# Altium schematic sheet Trottinette_Telemetrie_Connectors.SchDoc(Trottinette_Telemetrie_Connectors)
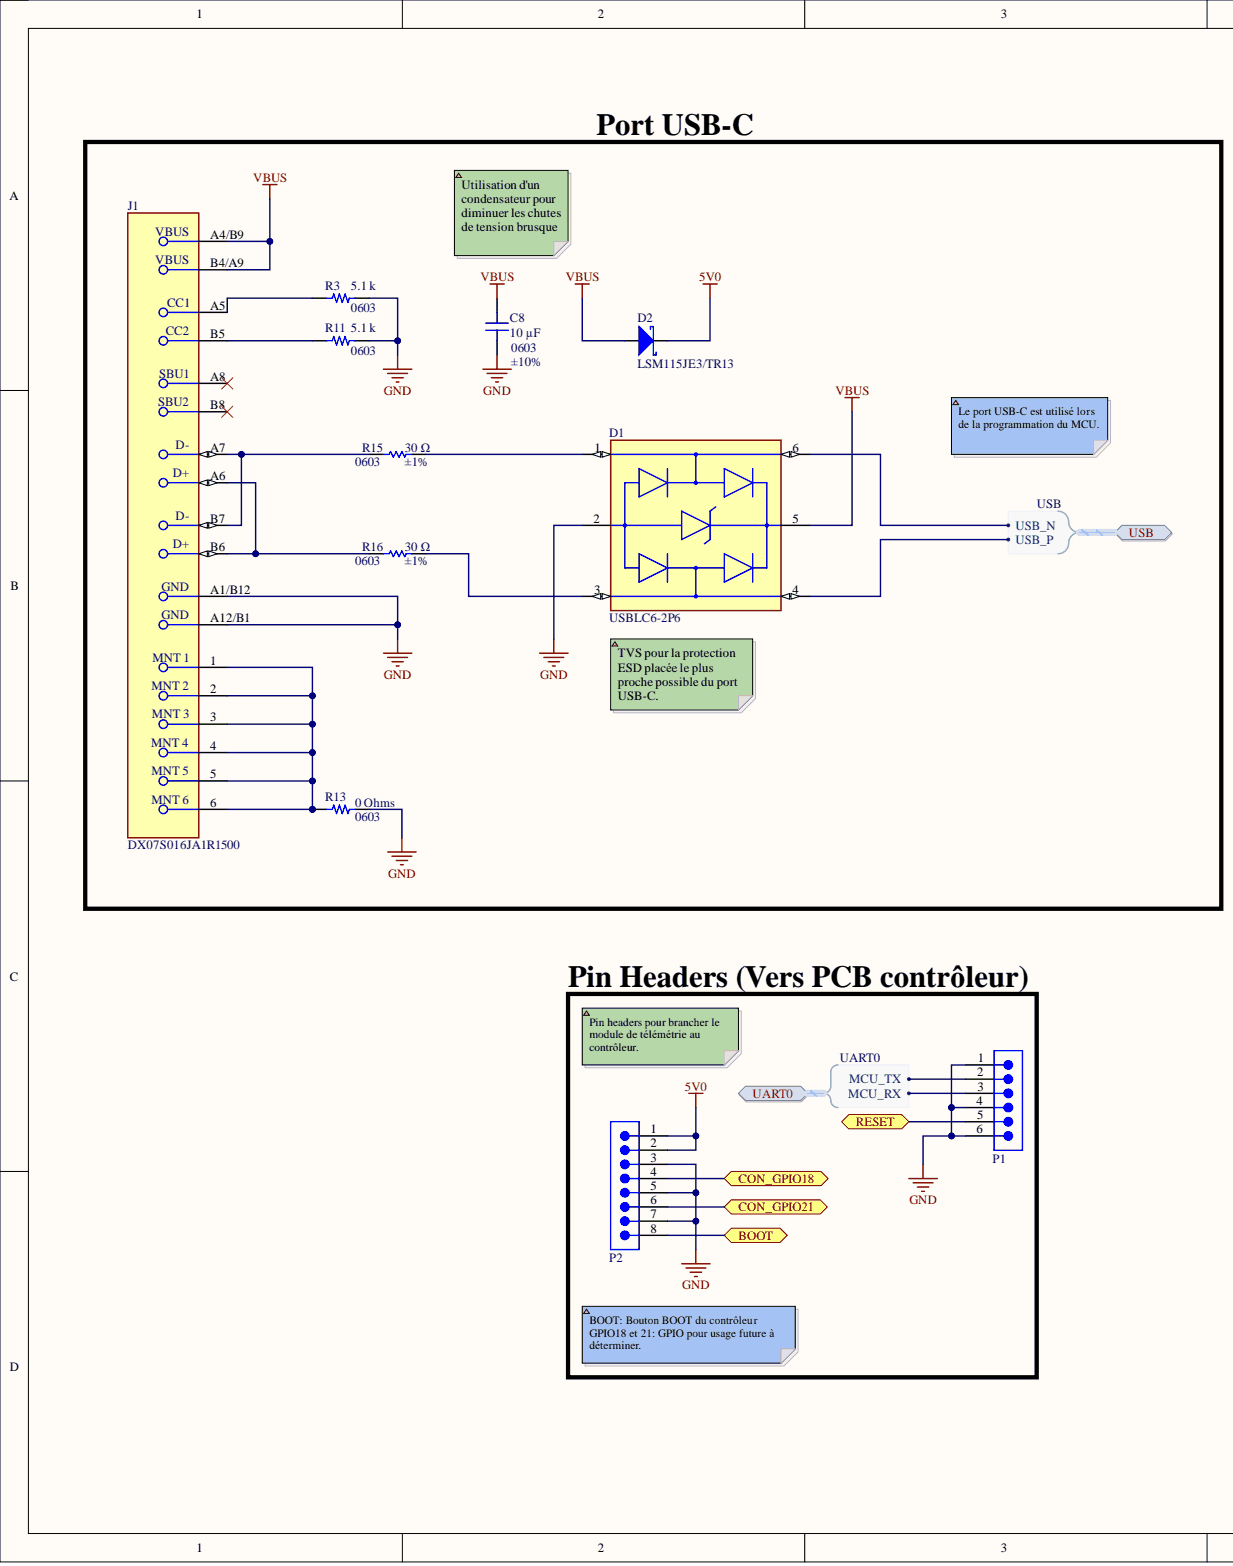
[diagram p0: Trottinette_Telemetrie_Connectors.SchDoc, left]
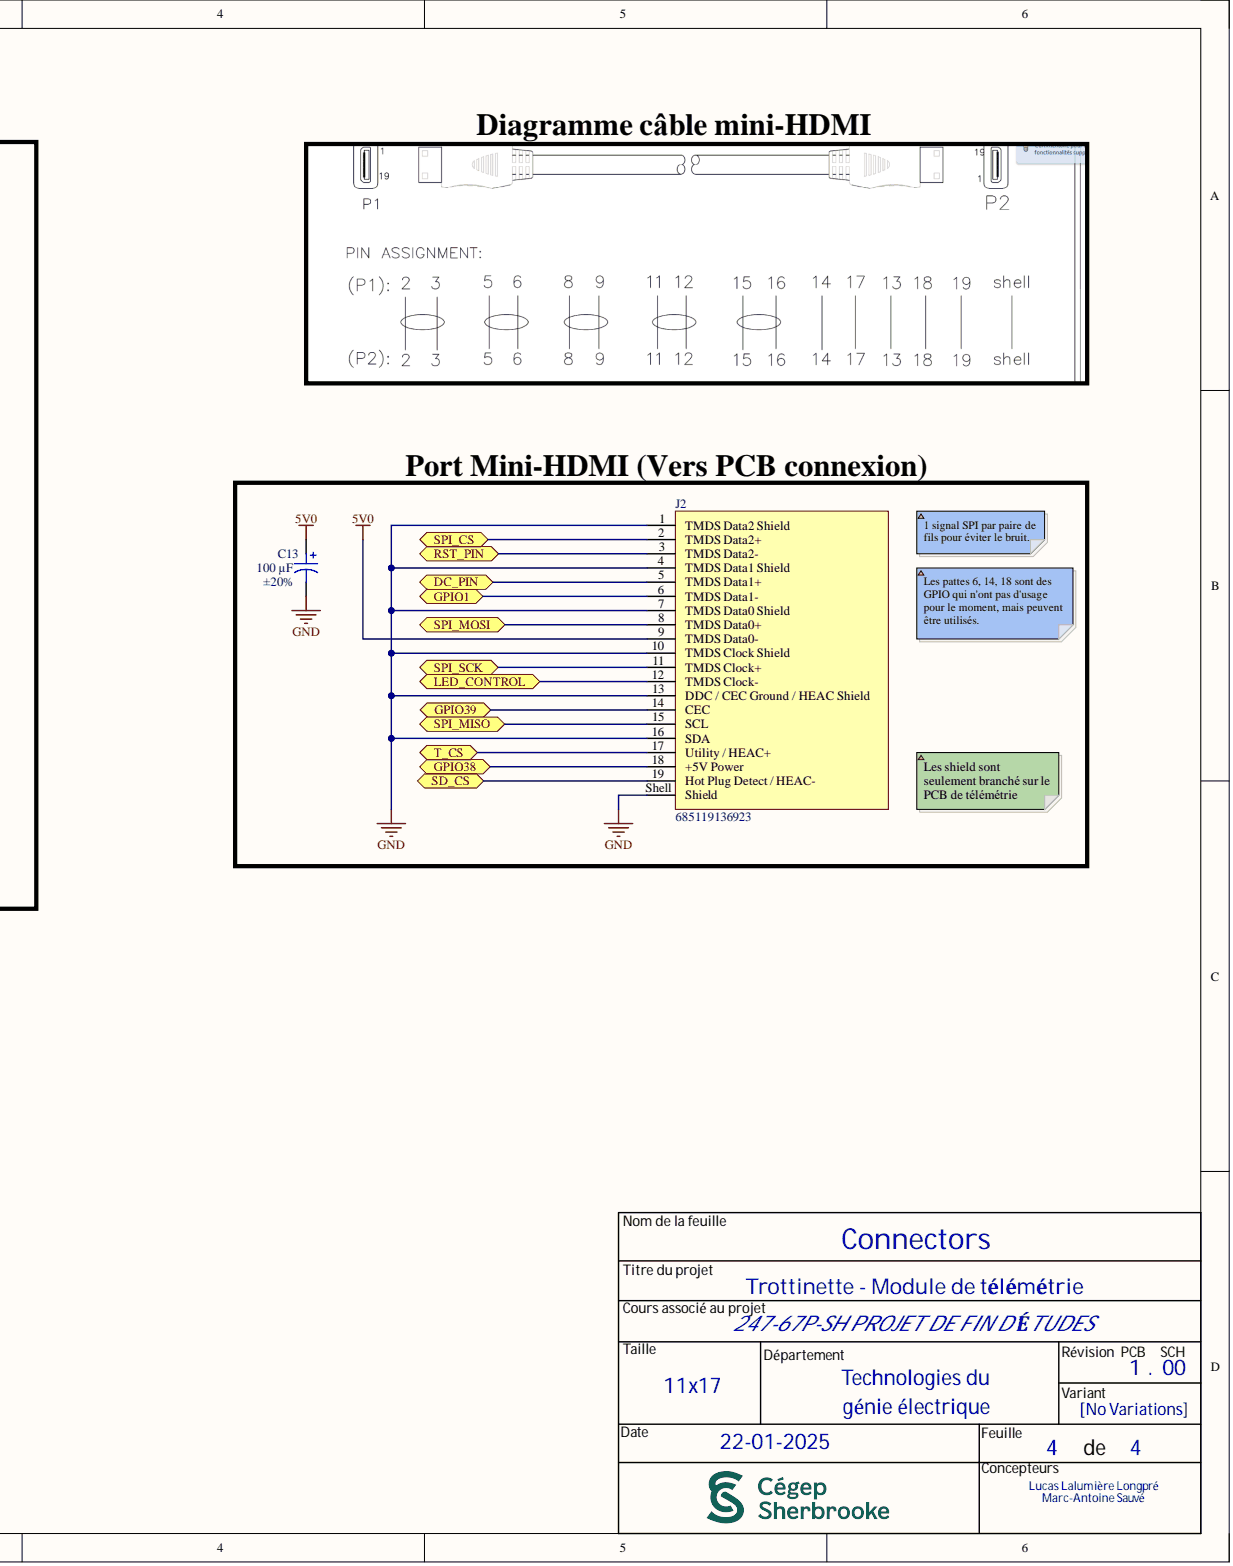
[diagram p1: Trottinette_Telemetrie_Connectors.SchDoc, right]

## Parts
C8  10 µF  Device Package: 0603  Tolerance: ±10%  [CAP 0603_1608]
C13  100 µF  Tolerance: ±20%  [CAP ALSMD 6.6MM H6.1MM]
D1  USBLC6-2P6  [FP-SOT-666-MFG]
D2  LSM115JE3/TR13  [DIOM4336X33N]
J1  DX07S016JA1R1500  [FP-DX07S016JA1R1500-MFG]
J2  685119136923  [685119136923]
P1  61300611121  [61300611121]  (value not drawn)
P2  61300811121  [61300811121]  (value not drawn)
R3  5.1 k  Package / Case: 0603  [RES 0603_1608]
R11  5.1 k  Package / Case: 0603  [RES 0603_1608]
R13  0 Ohms  Supplier Device Package: 0603  [RES 0603_1608]
R15  ERJ3EKF30R0V  [RESC1608X55X30LL15T15]  (value not drawn)
R16  ERJ3EKF30R0V  [RESC1608X55X30LL15T15]  (value not drawn)

## Ports
BOOT, CON_GPIO18, CON_GPIO21, DC_PIN, GPIO1, GPIO38, GPIO39, LED_CONTROL, RESET, RST_PIN, SD_CS, SPI_CS, SPI_MISO, SPI_MOSI, SPI_SCK, T_CS, UART0, UART0.MCU_RX, UART0.MCU_TX, USB, USB.USB_N, USB.USB_P

## Nets
5V0: C13.1 D2.1 J2.9(TMDS_Data0-) P2.1 P2.2
GND: C8.1 C13.2 D1.2 J1.A1/B12 J1.A12/B1 J2.1(TMDS_Data2_Shield) J2.4(TMDS_Data1_Shield) J2.7(TMDS_Data0_Shield) J2.10(TMDS_Clock_Shield) J2.13(DDC_/_CEC_Ground_/_HEAC_Shield) J2.16(SDA) J2.Shell(Shield) P1.1 P1.4 P1.6 P2.3 P2.5 P2.7 R3.1 R11.1 R13.2
VBUS: C8.2 D1.5 D2.2 J1.A4/B9 J1.B4/A9
BOOT: P2.8 ; port BOOT
CON_GPIO18: P2.4 ; port CON_GPIO18
CON_GPIO21: P2.6 ; port CON_GPIO21
DC_PIN: J2.5(TMDS_Data1+) ; port DC_PIN
GPIO1: J2.6(TMDS_Data1-) ; port GPIO1
GPIO38: J2.18(+5V_Power) ; port GPIO38
GPIO39: J2.14(CEC) ; port GPIO39
LED_CONTROL: J2.12(TMDS_Clock-) ; port LED_CONTROL
RESET: P1.5 ; port RESET
RST_PIN: J2.3(TMDS_Data2-) ; port RST_PIN
SD_CS: J2.19(Hot_Plug_Detect_/_HEAC-) ; port SD_CS
SPI_CS: J2.2(TMDS_Data2+) ; port SPI_CS
SPI_MISO: J2.15(SCL) ; port SPI_MISO
SPI_MOSI: J2.8(TMDS_Data0+) ; port SPI_MOSI
SPI_SCK: J2.11(TMDS_Clock+) ; port SPI_SCK
T_CS: J2.17(Utility_/_HEAC+) ; port T_CS
UART0.MCU_RX: P1.3 ; port UART0
UART0.MCU_TX: P1.2 ; port UART0
USB.USB_N: D1.6 ; port USB
USB.USB_P: D1.4 ; port USB
NetD1_1: D1.1 R15.2
NetD1_3: D1.3 R16.2
NetJ1_1: J1.1 J1.2 J1.3 J1.4 J1.5 J1.6 R13.1
NetJ1_A5: J1.A5 R3.2
NetJ1_A6: J1.A6 J1.B6 R16.1
NetJ1_A7: J1.A7 J1.B7 R15.1
NetJ1_B5: J1.B5 R11.2

Not connected: J1 A8 B8

## Library data of the parts
C8 = GRT188R61A106KE13D: CAP CER 10UF 10V X5R 0603; manufacturer: Murata Electronics; Database Table Name: Capacitors - Ceramic - 0603; Applications: Automotive; Capacitance: 10 µF; Component Kind: Standard; componentlink1description: Datasheet; componentlink1url: https://search.murata.co.jp/Ceramy/image/img/A01X/G101/ENG/GRT188R61A106KE13-01.pdf; componentlink2description: DigiKey Link; componentlink2url: https://www.digikey.com/en/products/detail/murata-electronics/GRT188R61A106KE13D/5416733; Features: Derating Recommended; lastupdated: 2/9/2024 8:10:30 PM; Library Name: Celestial Altium Library - altium_library.DbLib; Lifecycle Status: Active; Minimum Order: 1; Mounting Type: Surface Mount, MLCC; Operating Temperature: -55°C ~ 85°C; Package / Case: 0603 (1608 Metric); packaging: TapeAndReel; Part Number: GRT188R61A106KE13D; Part Status: Active; partid: 2522; price: 0.06; Ratings: AEC-Q200; series: GRT; Size / Dimension: 0.063" L x 0.031" W (1.60mm x 0.80mm); Supplier 1: DigiKey; Supplier Part Number 1: 490-12311-1-ND; Temperature Coefficient: X5R; Thickness (Max): 0.037" (0.95mm); Value: 10 µF; Voltage - Rated: 10V
C13 = 10SGV100M6.3X6.1: CAP ALUM 100UF 20% 10V SMD; manufacturer: Rubycon; Database Table Name: Capacitors - Aluminum - Electrolytic SMT; Lifetime @ Temp.: 2000 Hrs @ 105°C; Polarization: Polar; Ripple Current @ High Frequency: 85.2 mA @ 10 kHz; Ripple Current @ Low Frequency: 71 mA @ 120 Hz; Surface Mount Land Size: 0.260" L x 0.260" W (6.60mm x 6.60mm); Applications: Automotive; Capacitance: 100 µF; Component Kind: Standard; componentlink1description: Datasheet; componentlink1url: http://www.rubycon.co.jp/en/catalog/e_pdfs/aluminum/e_sgv.pdf; componentlink2description: DigiKey Link; componentlink2url: https://www.digikey.com/en/products/detail/rubycon/10SGV100M6-3X6-1/3568604; Device Package: Radial, Can - SMD; Height - Seated (Max): 0.240" (6.10mm); lastupdated: 6/3/2024 8:16:10 PM; Library Name: Celestial Altium Library - altium_library.DbLib; Lifecycle Status: Active; Minimum Order: 1; Mounting Type: Surface Mount; Operating Temperature: -55°C ~ 105°C; Package / Case: Radial, Can - SMD; packaging: TapeAndReel; Part Number: 10SGV100M6.3X6.1; Part Status: Active; partid: 8082; price: 0.08; Ratings: AEC-Q200; series: SGV; Size / Dimension: 0.248" Dia (6.30mm); Supplier 1: DigiKey; Supplier Part Number 1: 1189-2392-1-ND; value: 100 µF; Voltage - Rated: 10 V
D1 = CMP-12225-000058-1: TVS DIODE 5.25V 17V SOT666; Breakdown Voltage: 6V; Capacitance: 3.5pF; Direction: Unidirectional; Element Configuration: Dual; ESD Protection: Yes; Leakage Current: 150nA; Number of Bidirectional Channels: 2; Number of Elements: 1; Operating Supply Voltage: 5V; Peak Pulse Current: 5A; Test Current: 1mA; Case/Package: SOT-666; Clamping Voltage: 17V; Component Kind: Standard; Contact Plating: Tin; Depth: 1.3mm; Height: 0.6mm; Lead Free: Lead Free; Length: 1.7mm; Library Name: Altium Content Vault; Max Breakdown Voltage: 6V; Max Operating Temperature: 125°C; Max Reverse Leakage Current: 150nA; Min Breakdown Voltage: 6V; Min Operating Temperature: -40°C; Mount: Surface Mount; Number of Channels: 2; Number of Pins: 6; Package Quantity: 1; Packaging: Tape and Reel; Polarity: Unidirectional; Power Line Protection: Yes; Radiation Hardening: No; REACH SVHC: No SVHC; Reverse Breakdown Voltage: 6V; Reverse Standoff Voltage: 5V; Termination: SMD/SMT; Width: 1.3mm; Working Voltage: 5V
D2 = CMP-362-000004-1: DIODE SCHOTTKY 15V 1A DO214BA; Component Kind: Standard; Library Name: Cegep de Sherbrooke
J1 = CMP-19038-000003-1: CONN RCP USB2.0 TYP C 24P SMD RA; Component Kind: Standard; Library Name: Altium Content Vault
J2 = CMP-1502-00171-2: NexarPartId: 19499823; Body Material: Brass; Connector Type: HDMI; Contact Resistance: 30mΩ; Current Rating: 500mA; Gender: Receptacle; Insulation Resistance: 100MΩ; Number of Contacts: 19; Operating Supply Voltage: 40V; Component Kind: Standard; Contact Material: Gold; Contact Plating: Gold; Features: Shielded; Height: 3.45mm; Lead Free: Lead Free; Library Name: Altium Content Vault; Max Operating Temperature: 85°C; Min Operating Temperature: -25°C; Mount: Horizontal, Surface Mount; Number of Pins: 19; Number of Positions: 19; Orientation: Horizontal; Packaging: Tape and Reel; REACH SVHC: Unknown; RoHS: Compliant; Termination: SMD/SMT; Voltage Rating: 40V
P1 = 61300611121: WR-PHD Pin Header, THT, Vertical, pitch 2.54mm, 1 Row, 6P; Manufacturer: Wurth Elektronik; Contact Resistance: 20mOhm; Rated Current: 3A; Withstanding Voltage: 500V; Working Voltage: 250V; Category: Board-to-Board Connectors; Component Kind: Standard; ComponentLink1Description: Website Link; ComponentLink1URL: https://www.we-online.com/redexpert/article/61300611121?ad; ComponentLink2Description: Datasheet Link; ComponentLink2URL: https://www.we-online.com/redexpert/spec/61300611121?ad; Gender: Pin Header; Library Name: Altium_WR-PHD_rev24a.IntLib; Manufacturer Part Number: 61300611121; Match Code: WR-PHD; Mount: Through Hole; Number of Pins: 6; Number of Rows: 1; Operating Temperature Max: 105°C; Operating Temperature Min: -40°C; Orientation: Vertical; Packaging: Bag; Pitch: 2.54mm
P2 = 61300811121: WR-PHD Pin Header, THT, Vertical, pitch 2.54mm, 1 Row, 8P; Manufacturer: Wurth Elektronik; Contact Resistance: 20mOhm; Rated Current: 3A; Withstanding Voltage: 500V; Working Voltage: 250V; Category: Board-to-Board Connectors; Component Kind: Standard; ComponentLink1Description: Website Link; ComponentLink1URL: https://www.we-online.com/redexpert/article/61300811121?ad; ComponentLink2Description: Datasheet Link; ComponentLink2URL: https://www.we-online.com/redexpert/spec/61300811121?ad; Gender: Pin Header; Library Name: Altium_WR-PHD_rev24a.IntLib; Manufacturer Part Number: 61300811121; Match Code: WR-PHD; Mount: Through Hole; Number of Pins: 8; Number of Rows: 1; Operating Temperature Max: 105°C; Operating Temperature Min: -40°C; Orientation: Vertical; Packaging: Bag; Pitch: 2.54mm
R3 R11 = RMCF0603FT5K10: RES 5.1K OHM 1% 1/10W 0603; Manufacturer: Stackpole Electronics Inc; Database Table Name: Resistors - Surface Mount - 0603; Power (Watts): 0.1W, 1/10W; Resistance: 5.1 kOhms; Temperature Coefficient: ±100ppm/°C; Component Kind: Standard; ComponentLink1Description: Datasheet; ComponentLink1URL: https://www.seielect.com/catalog/sei-rmcf_rmcp.pdf; ComponentLink2Description: DigiKey Link; ComponentLink2URL: https://www.digikey.com/en/products/detail/stackpole-electronics-inc/RMCF0603FT5K10/1760908; Composition: Thick Film; Device Package: 0603 (1608 Metric); Features: Automotive AEC-Q200; Height - Seated (Max): 0.022" (0.55mm); LastUpdated: 2/9/2024 8:43:14 PM; Library Name: Celestial Altium Library - altium_library.DbLib; Lifecycle Status: Active; Minimum Order: 1; Number of Terminations: 2; Operating Temperature: -55°C ~ 155°C; Packaging: CutTape; Part Number: RMCF0603FT5K10; Part Status: Active; PartId: 10617; Price: 0.01; Ratings: AEC-Q200; Series: RMCF; Size / Dimension: 0.061" L x 0.031" W (1.55mm x 0.80mm); Supplier 1: DigiKey; Supplier Part Number 1: RMCF0603FT5K10CT-ND; Tolerance: ±1%; Value: 5.1 k
R13 = JR0603X26E: 0603 26 AMP JUMPER; Manufacturer: Ohmite; Database Table Name: Resistors - Surface Mount - 0603; Power (Watts): 0.135W; Component Kind: Standard; ComponentLink1Description: Datasheet; ComponentLink1URL: https://www.ohmite.com/assets/docs/res_jr.pdf?r=false; ComponentLink2Description: DigiKey Link; ComponentLink2URL: https://www.digikey.com/en/products/detail/ohmite/JR0603X26E/10233371; Composition: Metal Element; Device Package: 0603 (1608 Metric); Height - Seated (Max): 0.022" (0.55mm); LastUpdated: 2/9/2024 8:43:29 PM; Library Name: Celestial Altium Library - altium_library.DbLib; Lifecycle Status: Active; Minimum Order: 1; Number of Terminations: 2; Operating Temperature: -55°C ~ 150°C; Package / Case: 0603 (1608 Metric); Packaging: TapeAndReel; Part Number: JR0603X26E; Part Status: Active; PartId: 6812; Price: 0.10; Resistance: 0 Ohms; Series: JR; Size / Dimension: 0.063" L x 0.031" W (1.60mm x 0.80mm); Supplier 1: DigiKey; Supplier Part Number 1: JR0603X26ECT-ND; Tolerance: Jumper; Value: 0 Ohms
R15 R16 = CMP-2000-00423-1: Manufacturer: Panasonic; Component Kind: Standard; Library Name: Altium Content Vault; Part Number: ERJ3EKF30R0V
